# Altium schematic sheet GPIO48PIN.SchDoc(GPIO48PIN)
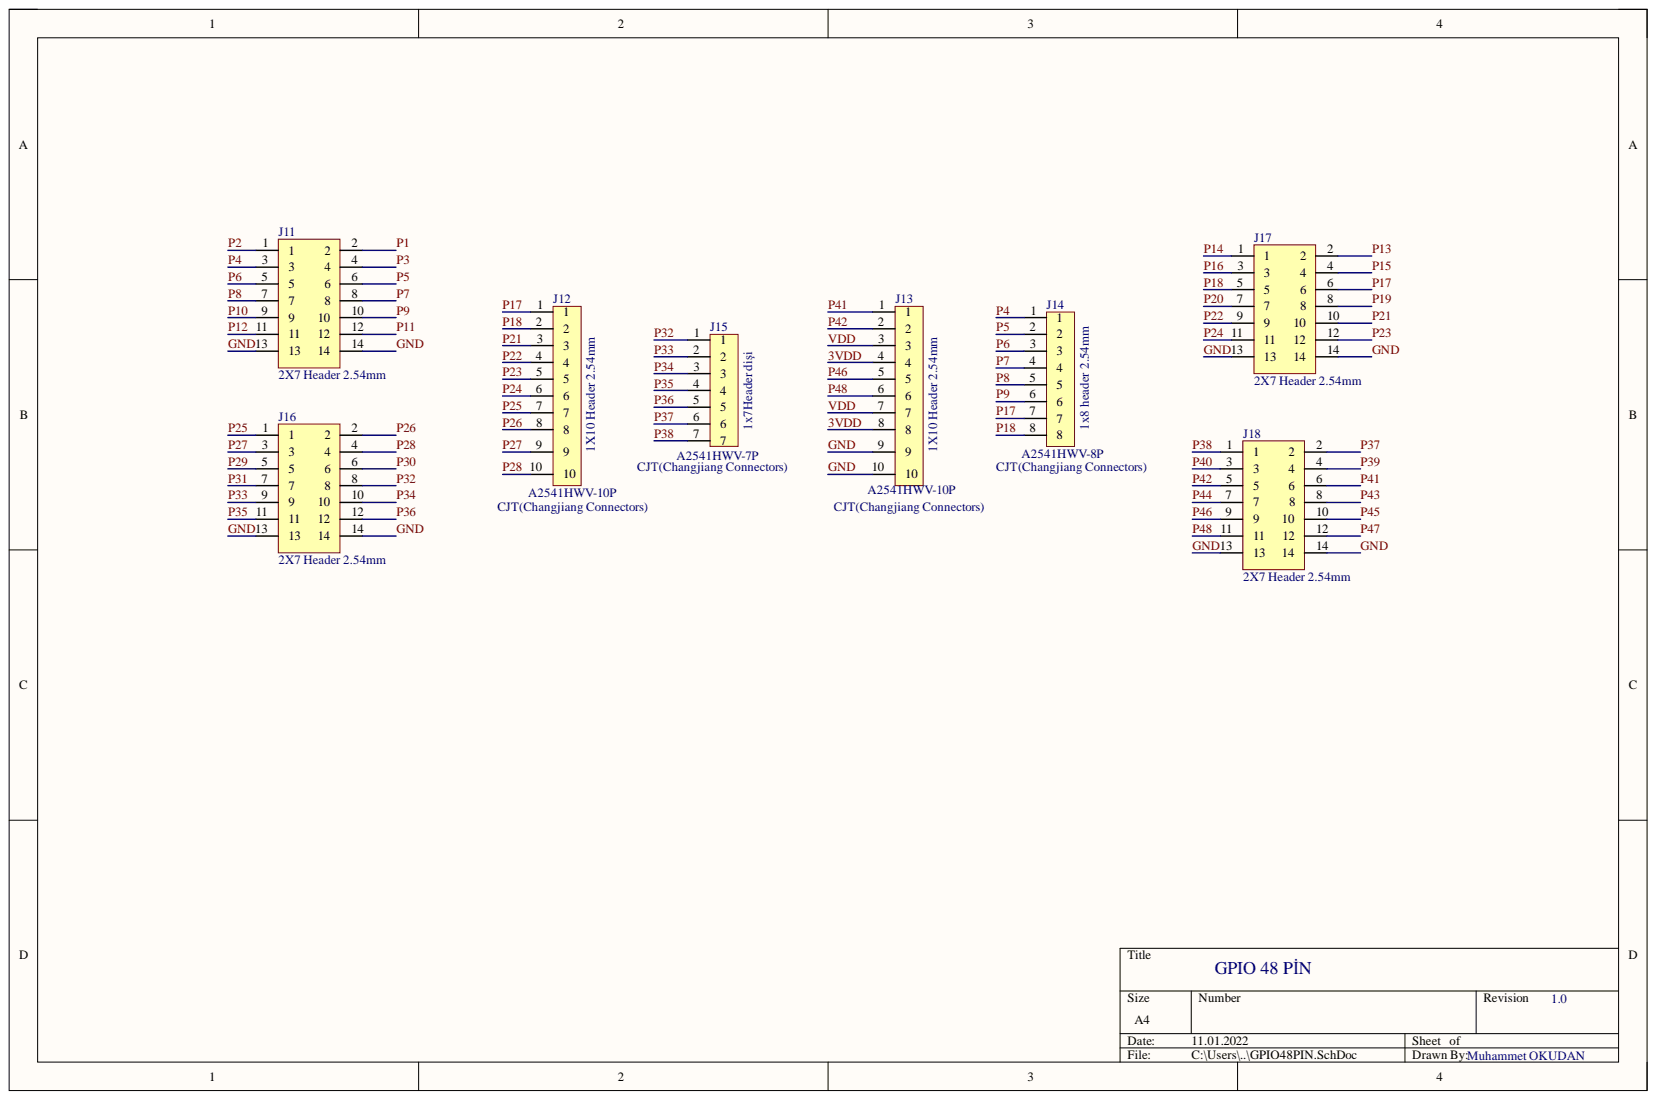
[diagram p0: GPIO48PIN.SchDoc]

## Parts
J11  2X7 Header 2.54mm  [2X7 HEADER 2.54MM]
J12  1X10 Header 2.54mm  *Mfg Part#: A2541HWV-10P  Manufacturer: CJT(Changjiang Connectors)  [1x10 header 2.54mm]
J13  1X10 Header 2.54mm  *Mfg Part#: A2541HWV-10P  Manufacturer: CJT(Changjiang Connectors)  [1x10 header 2.54mm]
J14  1x8 header 2.54mm  *Mfg Part#: A2541HWV-8P  Manufacturer: CJT(Changjiang Connectors)  [1X8 HEADER 2.54MM]
J15  1x7Header dişi  *Mfg Part#: A2541HWV-7P  Manufacturer: CJT(Changjiang Connectors)  [1x7 header 2.54 mm,]
J16  2X7 Header 2.54mm  [2X7 HEADER 2.54MM]
J17  2X7 Header 2.54mm  [2X7 HEADER 2.54MM]
J18  2X7 Header 2.54mm  [2X7 HEADER 2.54MM]

## Nets
3VDD: J13.4 J13.8
GND: J11.13 J11.14 J13.9 J13.10 J16.13 J16.14 J17.13 J17.14 J18.13 J18.14
P1: J11.2
P2: J11.1
P3: J11.4
P4: J11.3 J14.1
P5: J11.6 J14.2
P6: J11.5 J14.3
P7: J11.8 J14.4
P8: J11.7 J14.5
P9: J11.10 J14.6
P10: J11.9
P11: J11.12
P12: J11.11
P13: J17.2
P14: J17.1
P15: J17.4
P16: J17.3
P17: J12.1 J14.7 J17.6
P18: J12.2 J14.8 J17.5
P19: J17.8
P20: J17.7
P21: J12.3 J17.10
P22: J12.4 J17.9
P23: J12.5 J17.12
P24: J12.6 J17.11
P25: J12.7 J16.1
P26: J12.8 J16.2
P27: J12.9 J16.3
P28: J12.10 J16.4
P29: J16.5
P30: J16.6
P31: J16.7
P32: J15.1 J16.8
P33: J15.2 J16.9
P34: J15.3 J16.10
P35: J15.4 J16.11
P36: J15.5 J16.12
P37: J15.6 J18.2
P38: J15.7 J18.1
P39: J18.4
P40: J18.3
P41: J13.1 J18.6
P42: J13.2 J18.5
P43: J18.8
P44: J18.7
P45: J18.10
P46: J13.5 J18.9
P47: J18.12
P48: J13.6 J18.11
VDD: J13.3 J13.7

## Library data of the parts
J11 J16-J18 = 2X7 Header 2.54mm: Component Kind: Standard; Library Name: NUV.SchLib
J12 J13 = 1X10 Header 2.54mm: Component Kind: Standard; Library Name: NUV.SchLib
J14 = 1x8 header 2.54mm: Component Kind: Standard; Library Name: NUV.SchLib
J15 = 1x7Header dişi: Component Kind: Standard; Library Name: NUV.SchLib
